# Altium schematic sheet POWER.SchDoc
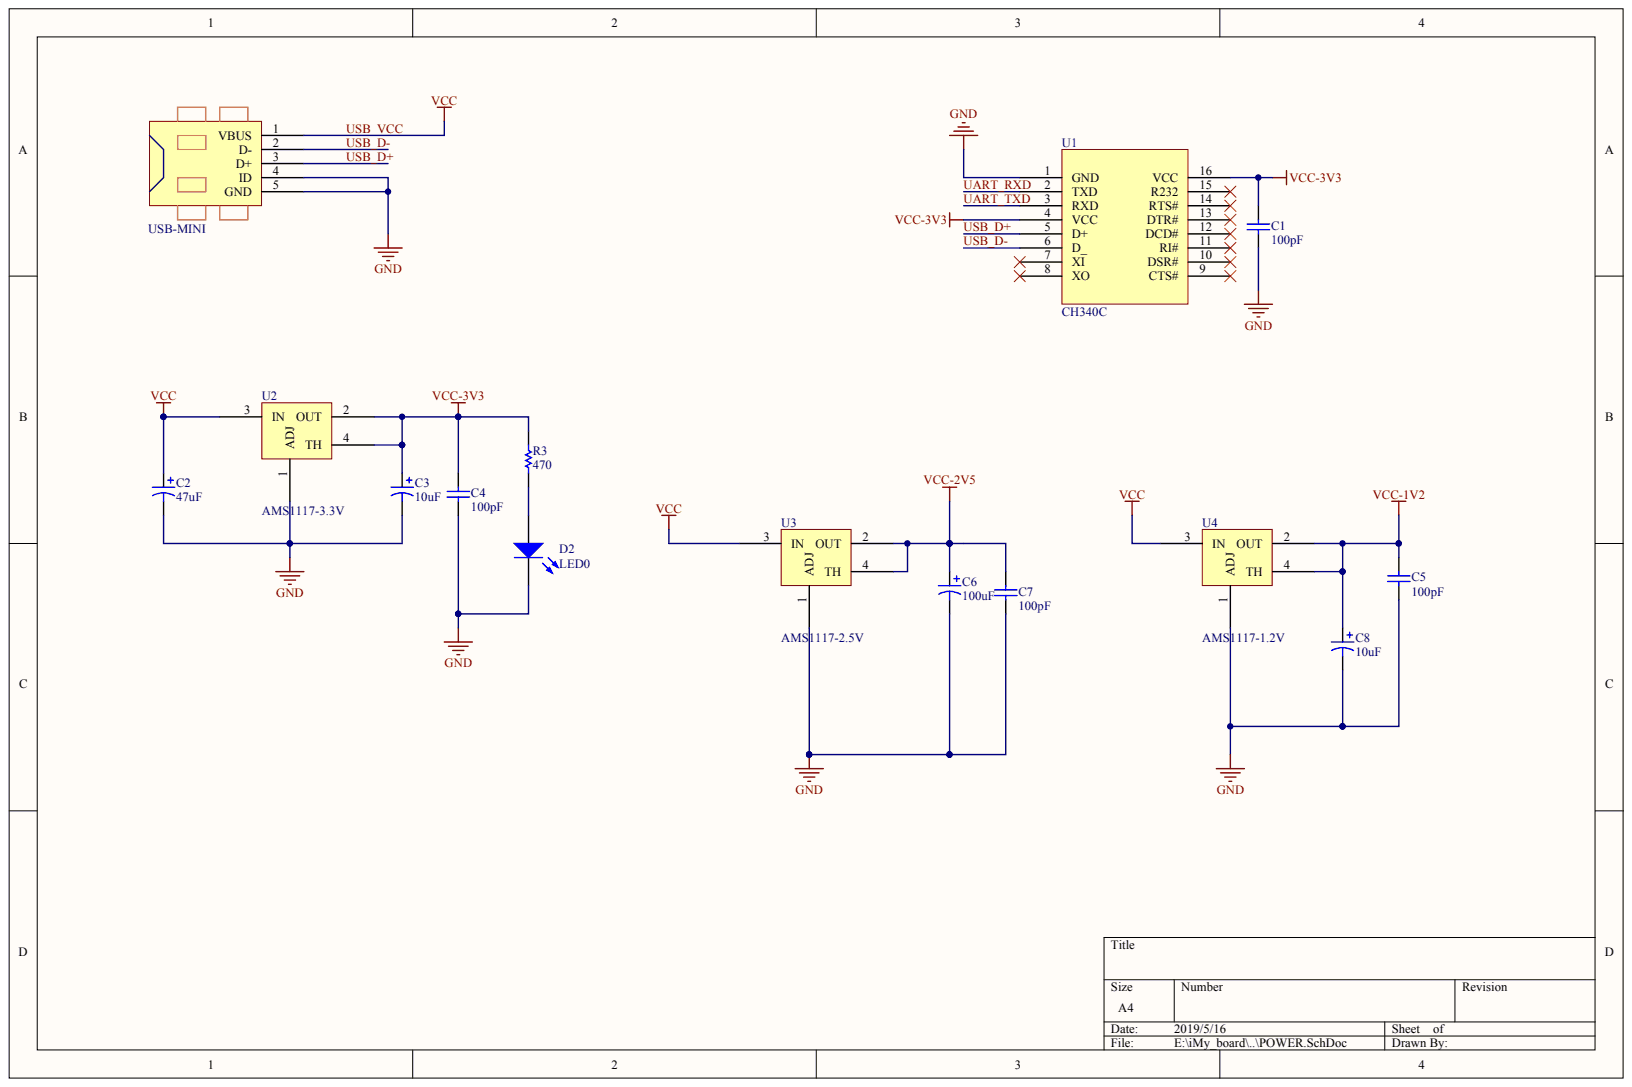
[diagram p0: POWER.SchDoc]

## Parts
C1  value 100pF  (hidden comment: Cap)
C2  value 47uF  (hidden comment: Cap Pol1)
C3  value 10uF  (hidden comment: Cap Pol1)
C4  value 100pF  (hidden comment: Cap)
C5  value 100pF  (hidden comment: Cap)
C6  value 100uF  (hidden comment: Cap Pol1)
C7  value 100pF  (hidden comment: Cap)
C8  value 10uF  (hidden comment: Cap Pol1)
D2  LED0
R3  value 470  (hidden comment: Res1)
U1  CH340C
U2  AMS1117-3.3V
U3  AMS1117-2.5V
U4  AMS1117-1.2V
USB-MINI1  USB-MINI

## Nets
GND: C1.1 C2.2 C3.2 C4.1 C5.1 C6.2 C7.1 C8.2 D2.2 U1.1(GND) U2.1(ADJ) U3.1(ADJ) U4.1(ADJ) USB-MINI1.4(ID) USB-MINI1.5(GND)
VCC-1V2: C5.2 C8.1 U4.2(OUT) U4.4(TH)
VCC-2V5: C6.1 C7.2 U3.2(OUT) U3.4(TH)
VCC-3V3: C1.2 C3.1 C4.2 R3.2 U1.4(VCC) U1.16(VCC) U2.2(OUT) U2.4(TH)
UART_RXD: U1.2(TXD)
UART_TXD: U1.3(RXD)
USB_D+: U1.5(D+) USB-MINI1.3(D+)
USB_D-: U1.6(D_) USB-MINI1.2(D-)
USB_VCC: C2.1 U2.3(IN) U3.3(IN) U4.3(IN) USB-MINI1.1(VBUS)
NetD2_1: D2.1 R3.1

Not connected: U1 7(XI) 8(XO) 9(CTS#) 10(DSR#) 11(RI#) 12(DCD#) 13(DTR#) 14(RTS#) 15(R232)

## Library data of the parts
C1-C8 D2 R3 U1-U4 USB-MINI1 = (no symbol name)
  C1 C4 C5 C7: Published: 8-Jun-2000; LatestRevisionDate: 17-Jul-2002; LatestRevisionNote: Re-released for DXP Platform.; PackageReference: RAD-0.3; PackageDescription: Radial Cap, Thru-Hole; 2 Leads; 0.3 in Pin Spacing
  C2 C3 C6 C8: Published: 8-Jun-2000; LatestRevisionDate: 17-Jul-2002; LatestRevisionNote: Re-released for DXP Platform.; PackageReference: RB7.6-15; PackageDescription: Polarized Capacitor (Radial); 2 Leads
  D2: Published: 8-Jun-2000; LatestRevisionDate: 08-Jul-2005; LatestRevisionNote: Mech Layer 1 removed.; PackageReference: LED-0; PackageDescription: LED; 2 Leads
  R3: Published: 8-Jun-2000; LatestRevisionDate: 17-Jul-2002; LatestRevisionNote: Re-released for DXP Platform.; PackageReference: AXIAL-0.3; PackageDescription: Axial Device, Thru-Hole; 2 Leads; 0.3 in Pin Spacing
  C1-C8 D2 R3: Publisher: Altium Limited
